# Altium schematic sheet MIO 500-502.SchDoc("MIO 500-502")
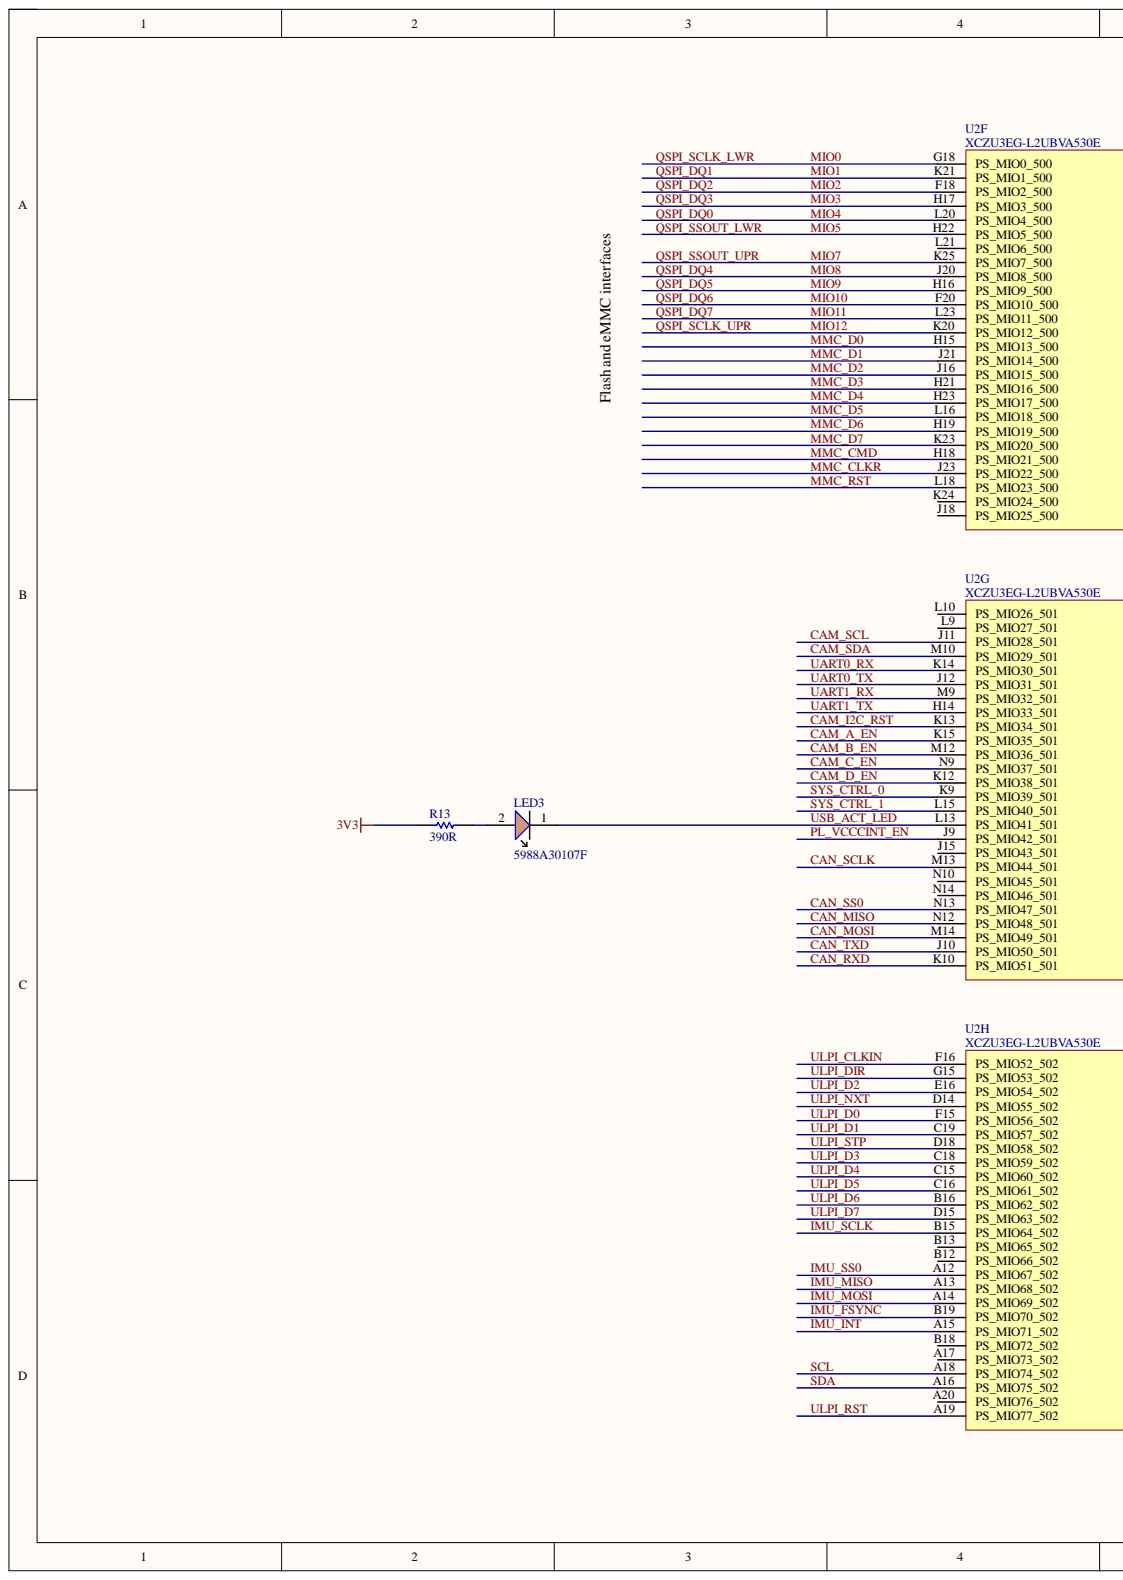
[diagram p0: MIO 500-502.SchDoc, left]
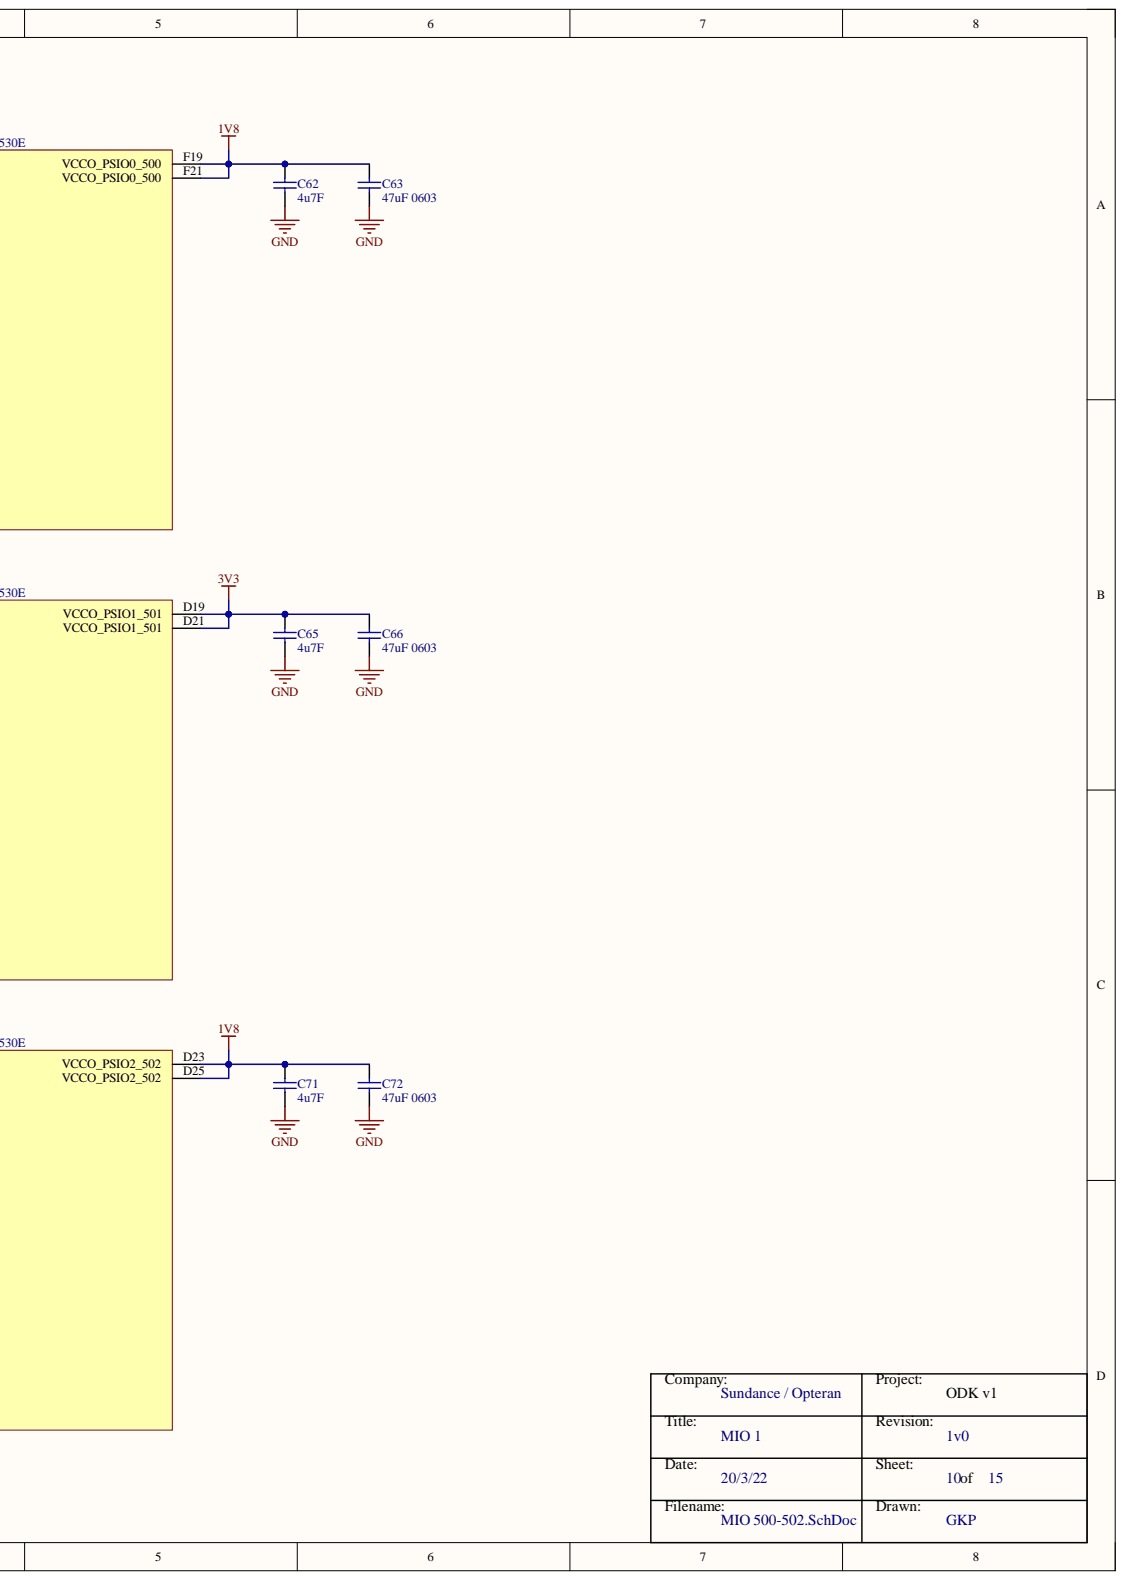
[diagram p1: MIO 500-502.SchDoc, right]

## Parts
C62  4u7F  [0201panERJ]
C63  47uF 0603  Case-EIA: 0603  [CAP0603]
C65  4u7F  [0201panERJ]
C66  47uF 0603  Case-EIA: 0603  [CAP0603]
C71  4u7F  [0201panERJ]
C72  47uF 0603  Case-EIA: 0603  [CAP0603]
LED3  5988A30107F  [LED0402 - ORG]
R13  390R  [RES0201]
U2  XCZU3EG-L2UBVA530E  [UBVA530]  (parts F,G,H)

## Nets
1V8: C62.2 C63.2 C71.2 C72.2 U2.D23(VCCO_PSIO2_502) U2.D25(VCCO_PSIO2_502) U2.F19(VCCO_PSIO0_500) U2.F21(VCCO_PSIO0_500)
3V3: C65.2 C66.2 R13.2 U2.D19(VCCO_PSIO1_501) U2.D21(VCCO_PSIO1_501)
GND: C62.1 C63.1 C65.1 C66.1 C71.1 C72.1
CAM_A_EN: U2.K15(PS_MIO35_501)
CAM_B_EN: U2.M12(PS_MIO36_501)
CAM_C_EN: U2.N9(PS_MIO37_501)
CAM_D_EN: U2.K12(PS_MIO38_501)
CAM_I2C_RST: U2.K13(PS_MIO34_501)
CAM_SCL: U2.J11(PS_MIO28_501)
CAM_SDA: U2.M10(PS_MIO29_501)
CAN_MISO: U2.N12(PS_MIO48_501)
CAN_MOSI: U2.M14(PS_MIO49_501)
CAN_RXD: U2.K10(PS_MIO51_501)
CAN_SCLK: U2.M13(PS_MIO44_501)
CAN_SS0: U2.N13(PS_MIO47_501)
CAN_TXD: U2.J10(PS_MIO50_501)
IMU_FSYNC: U2.B19(PS_MIO70_502)
IMU_INT: U2.A15(PS_MIO71_502)
IMU_MISO: U2.A13(PS_MIO68_502)
IMU_MOSI: U2.A14(PS_MIO69_502)
IMU_SCLK: U2.B15(PS_MIO64_502)
IMU_SS0: U2.A12(PS_MIO67_502)
MIO0: U2.G18(PS_MIO0_500)
MIO1: U2.K21(PS_MIO1_500)
MIO2: U2.F18(PS_MIO2_500)
MIO3: U2.H17(PS_MIO3_500)
MIO4: U2.L20(PS_MIO4_500)
MIO5: U2.H22(PS_MIO5_500)
MIO7: U2.K25(PS_MIO7_500)
MIO8: U2.J20(PS_MIO8_500)
MIO9: U2.H16(PS_MIO9_500)
MIO10: U2.F20(PS_MIO10_500)
MIO11: U2.L23(PS_MIO11_500)
MIO12: U2.K20(PS_MIO12_500)
MMC_CLKR: U2.J23(PS_MIO22_500)
MMC_CMD: U2.H18(PS_MIO21_500)
MMC_D0: U2.H15(PS_MIO13_500)
MMC_D1: U2.J21(PS_MIO14_500)
MMC_D2: U2.J16(PS_MIO15_500)
MMC_D3: U2.H21(PS_MIO16_500)
MMC_D4: U2.H23(PS_MIO17_500)
MMC_D5: U2.L16(PS_MIO18_500)
MMC_D6: U2.H19(PS_MIO19_500)
MMC_D7: U2.K23(PS_MIO20_500)
MMC_RST: U2.L18(PS_MIO23_500)
PL_VCCCINT_EN: U2.J9(PS_MIO42_501)
SCL: U2.A18(PS_MIO74_502)
SDA: U2.A16(PS_MIO75_502)
SYS_CTRL_0: U2.K9(PS_MIO39_501)
SYS_CTRL_1: U2.L15(PS_MIO40_501)
UART0_RX: U2.K14(PS_MIO30_501)
UART0_TX: U2.J12(PS_MIO31_501)
UART1_RX: U2.M9(PS_MIO32_501)
UART1_TX: U2.H14(PS_MIO33_501)
ULPI_CLKIN: U2.F16(PS_MIO52_502)
ULPI_D0: U2.F15(PS_MIO56_502)
ULPI_D1: U2.C19(PS_MIO57_502)
ULPI_D2: U2.E16(PS_MIO54_502)
ULPI_D3: U2.C18(PS_MIO59_502)
ULPI_D4: U2.C15(PS_MIO60_502)
ULPI_D5: U2.C16(PS_MIO61_502)
ULPI_D6: U2.B16(PS_MIO62_502)
ULPI_D7: U2.D15(PS_MIO63_502)
ULPI_DIR: U2.G15(PS_MIO53_502)
ULPI_NXT: U2.D14(PS_MIO55_502)
ULPI_RST: U2.A19(PS_MIO77_502)
ULPI_STP: U2.D18(PS_MIO58_502)
USB_ACT_LED: LED3.1 U2.L13(PS_MIO41_501)
NetLED3_2: LED3.2 R13.1

Not connected: U2 A17(PS_MIO73_502) A20(PS_MIO76_502) B12(PS_MIO66_502) B13(PS_MIO65_502) B18(PS_MIO72_502) J15(PS_MIO43_501) J18(PS_MIO25_500) K24(PS_MIO24_500) L9(PS_MIO27_501) L10(PS_MIO26_501) L21(PS_MIO6_500) N10(PS_MIO45_501) N14(PS_MIO46_501)

## Library data of the parts
C62 C65 C71 = Cap0201: 4u7F 0201 6V3 X5R; Case-EIA: 0402; Component Kind: Standard; Library Name: Schematic Components.SchLib; Max Thickness: 0.6 mm; Technology: SMT
C63 C66 C72 = Cap0603: 47uF 6V3 0603 X5R; Component Kind: Standard; Library Name: Schematic Components.SchLib; Max Thickness: 0.6 mm; Technology: SMT
LED3 = LED 0402 ORG: Orange 605nm LED Indication - Discrete 1.8V 0402 (1005 Metric); Manufacturer 1: DIALIGHT; Component Kind: Standard; Library Name: Schematic Components.SchLib; Manufacturer Part Number 1: 5988A30107F; Supplier 1: Digikey; Supplier Part Number 1: 350-4448-2-ND
R13 = Res0201: 390R 0201 1/16W 1%; Value: ?k; Component Kind: Standard; Library Name: Schematic Components.SchLib
U2 = XCZU3EG-L2UBVA530E: SoC 32-bit/64-bit ARM Cortex-A53 RISC 1.5GHz Quad Core 530-Pin BGA; Manufacturer 1: Xilinx; Component Kind: Standard; Library Name: Xilinx1.SchLib; Manufacturer Part Number 1: XCZU3EG-L2UBVA530E; Supplier 1: Avnet; Supplier Part Number 1: XCZU3EG-L2UBVA530E
